# Altium schematic sheet 00_POWER.SchDoc
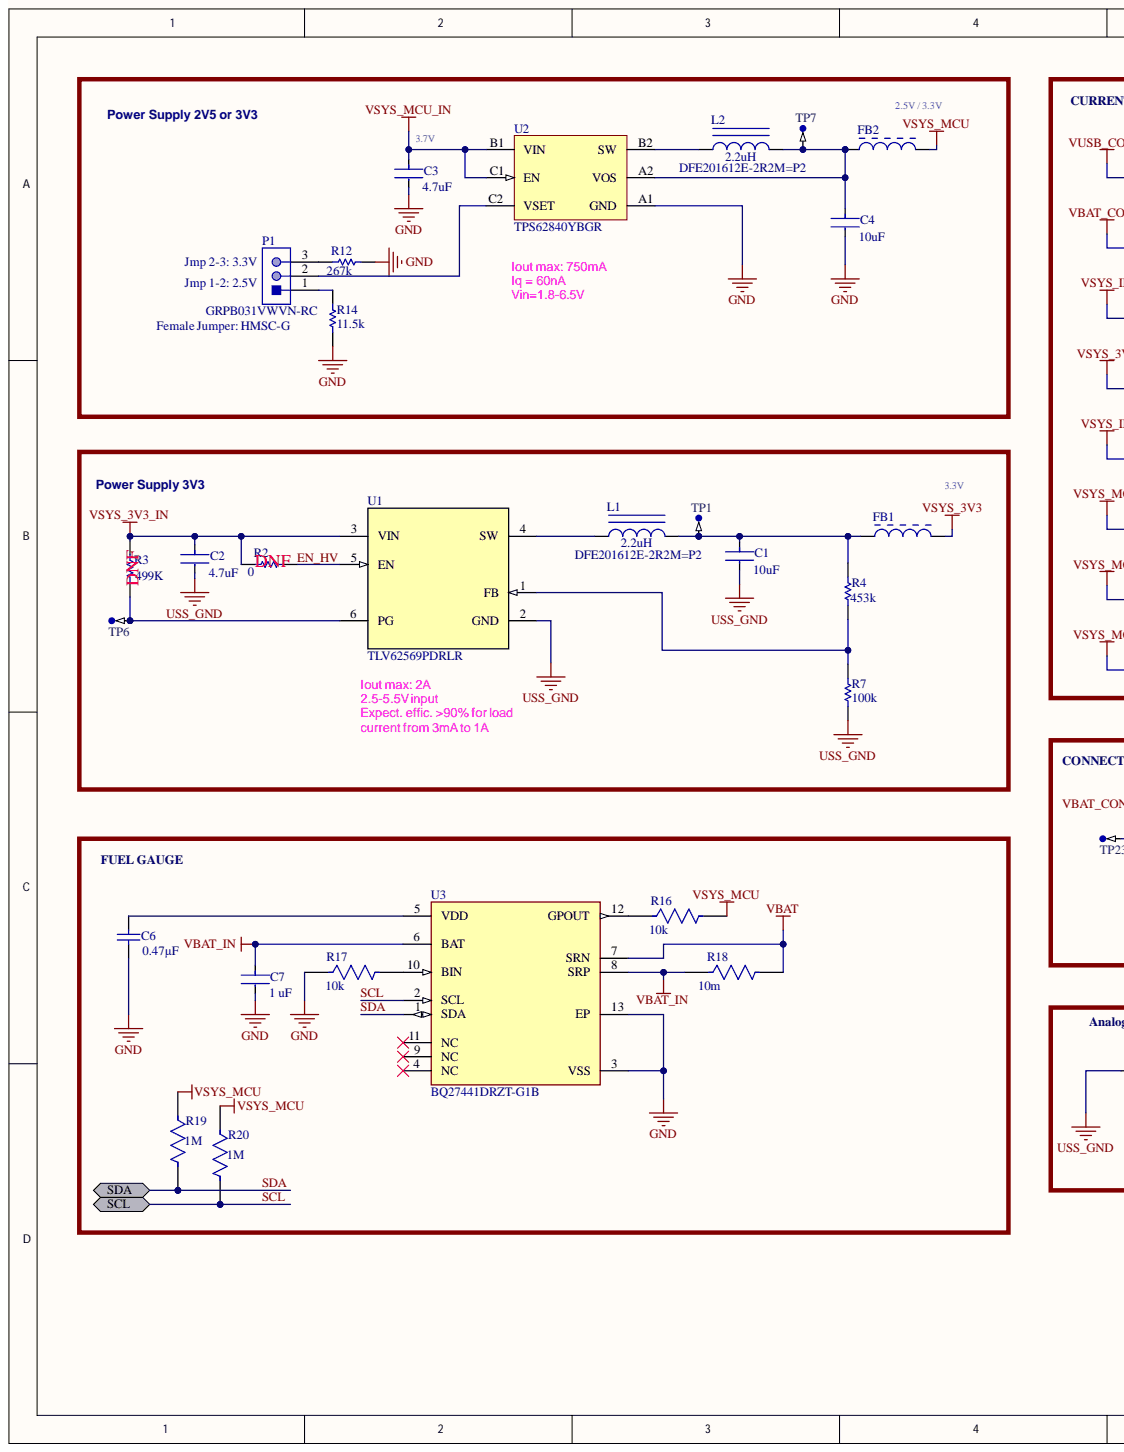
[diagram p0: 00_POWER.SchDoc, left]
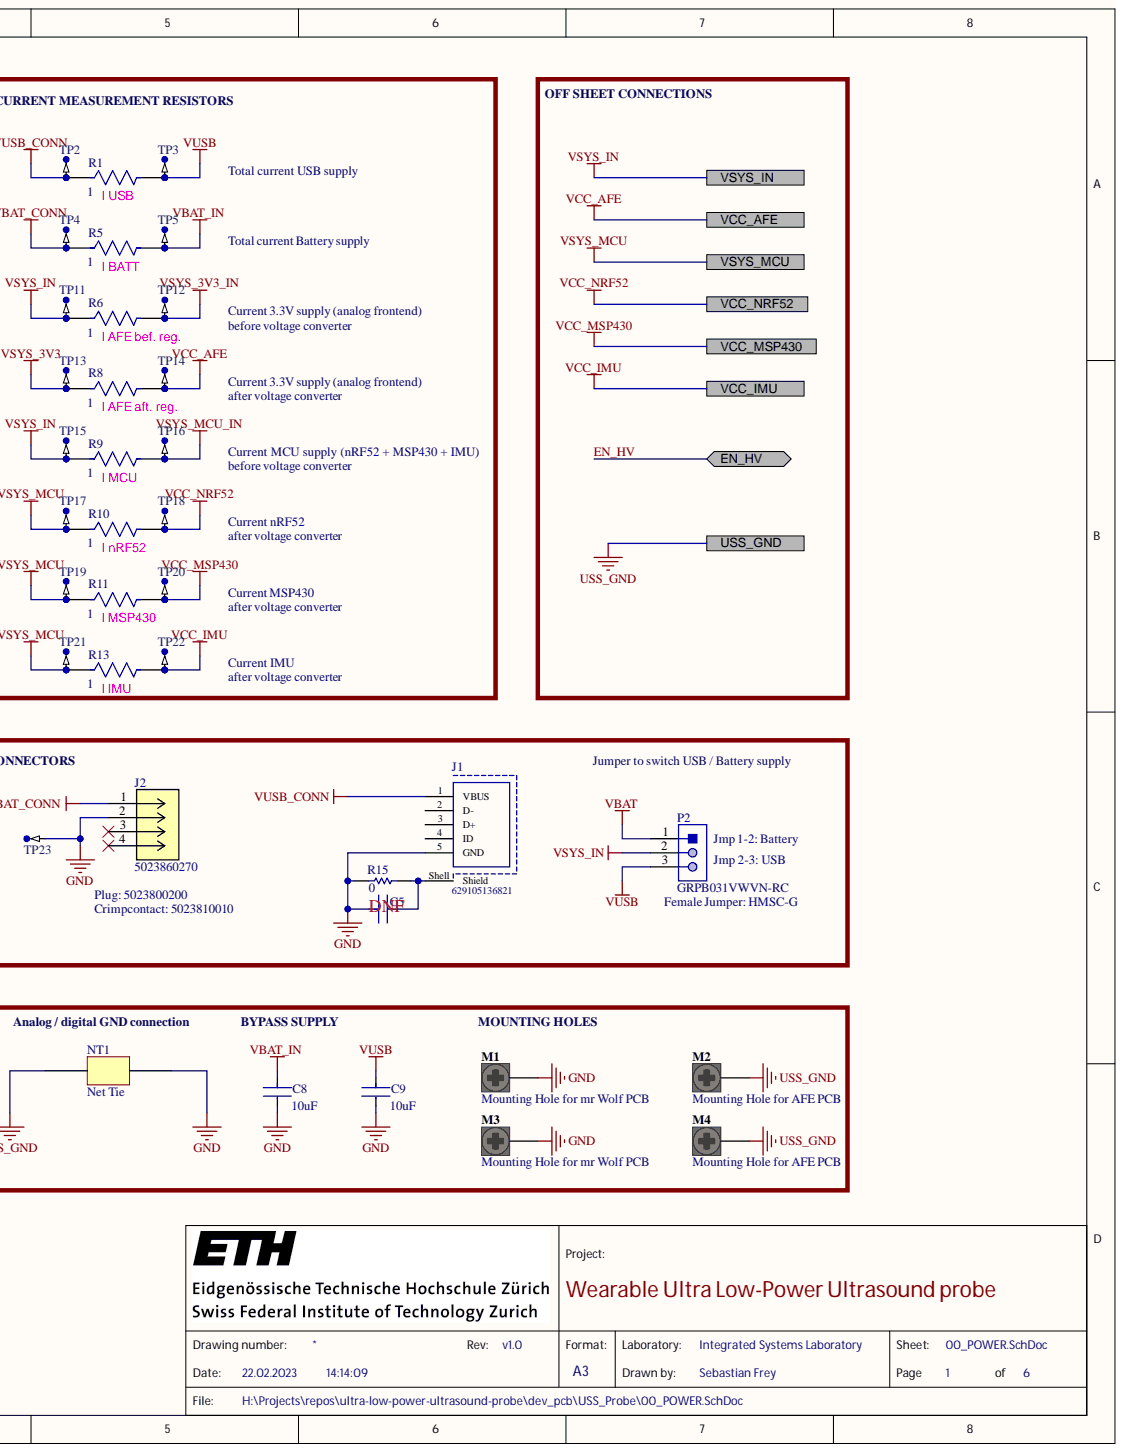
[diagram p1: 00_POWER.SchDoc, right]

## Parts
C1  value 10uF  Capacitance: 10uF  [FP-C1608-080-MFG]  (hidden comment: C1608X5R1A106K080AC)
C2  Capacitance: 4.7uF  [FP-0603-L_1_6_0_15-W_0_8-IPC_A]  (hidden comment: EMK107ABJ475KA-T)
C3  Capacitance: 4.7uF  [FP-0603-L_1_6_0_15-W_0_8-IPC_A]  (hidden comment: EMK107ABJ475KA-T)
C4  value 10uF  Capacitance: 10uF  [FP-C1608-080-MFG]  (hidden comment: C1608X5R1A106K080AC)
C5  CC0402KRX7R7BB104  [FP-CC0402-MFG]  (value not drawn)
C6  Capacitance: 0.47µF  [CAPC1050X55X10LL05]  (hidden comment: C1005X5R1E474K050BB)
C7  value 1 uF  Capacitance: 1 uF  [FP-CC0402-MFG]  (hidden comment: CC0402KRX5R5BB105)
C8  value 10uF  Capacitance: 10uF  [FP-C1608-080-MFG]  (hidden comment: C1608X5R1A106K080AC)
C9  value 10uF  Capacitance: 10uF  [FP-C1608-080-MFG]  (hidden comment: C1608X5R1A106K080AC)
FB1  BLM03AX800SN1D  [MURA-BLM03-CHIP-2_V]  (value not drawn)
FB2  BLM03AX800SN1D  [MURA-BLM03-CHIP-2_V]  (value not drawn)
J1  629105136821  [629105136821]
J2  5023860270  [CON_5023860270_MOL]
L1  DFE201612E-2R2M=P2  value 2.2uH  [INDC2016X10L]
L2  DFE201612E-2R2M=P2  value 2.2uH  [INDC2016X10L]
M1  Mounting Hole for mr Wolf PCB  ClassName: Mounting Hole  [Hole M2]  (no BOM)
M2  Mounting Hole for AFE PCB  ClassName: Mounting Hole  [Hole M2]  (no BOM)
M3  Mounting Hole for mr Wolf PCB  ClassName: Mounting Hole  [Hole M2]  (no BOM)
M4  Mounting Hole for AFE PCB  ClassName: Mounting Hole  [Hole M2]  (no BOM)
NT1  Net Tie  [Net_Tie_Top_Bottom]
P1  GRPB031VWVN-RC  [SLIN-GRPB031VWVN-RC_V]
P2  GRPB031VWVN-RC  [SLIN-GRPB031VWVN-RC_V]
R1  CSR0402FK1R00  [FP-CSR0402-MFG]  (value not drawn)
R2  RC0402JR-070RL  [RESC1005X40X25NL05T10]  (value not drawn)
R3  value 499K  [RESC0402(1005)_N]  (hidden comment: not mounted)
R4  Resistance: 453k  [RESC1005X40X25NL05T10]  (hidden comment: RC0402FR-07453KL)
R5  CSR0402FK1R00  [FP-CSR0402-MFG]  (value not drawn)
R6  CSR0402FK1R00  [FP-CSR0402-MFG]  (value not drawn)
R7  Resistance (Ohms): 100k  [RESC1005X40X25LL10T15]  (hidden comment: RMCF0402FT100K)
R8  CSR0402FK1R00  [FP-CSR0402-MFG]  (value not drawn)
R9  CSR0402FK1R00  [FP-CSR0402-MFG]  (value not drawn)
R10  CSR0402FK1R00  [FP-CSR0402-MFG]  (value not drawn)
R11  CSR0402FK1R00  [FP-CSR0402-MFG]  (value not drawn)
R12  Resistance: 267k  [RESC1005X40X25LL05T05]  (hidden comment: CRCW0402267KFKED)
R13  CSR0402FK1R00  [FP-CSR0402-MFG]  (value not drawn)
R14  Resistance: 11.5k  [RESC1005X40X25ML05T10]  (hidden comment: RC0402FR-0711K5L)
R15  RC0402JR-070RL  [RESC1005X40X25NL05T10]  (value not drawn)
R16  value 10k  [FP-TNPW0402-e3-MFG]  (hidden comment: TNPW040210K0BEED)
R17  value 10k  [FP-TNPW0402-e3-MFG]  (hidden comment: TNPW040210K0BEED)
R18  Resistance: 10m  [FP-CSRF0402-high-IPC_A]  (hidden comment: CSRF0402FT10L0)
R19  Resistance: 1M  [FP-CR0402-MFG]  (hidden comment: CR0402-JW-105GLF)
R20  Resistance: 1M  [FP-CR0402-MFG]  (hidden comment: CR0402-JW-105GLF)
TP1  TP-SM-C-100  [TP-SM-C-100]  (value not drawn)  (no BOM)
TP2  TP-SM-C-100  [TP-SM-C-100]  (value not drawn)  (no BOM)
TP3  TP-SM-C-100  [TP-SM-C-100]  (value not drawn)  (no BOM)
TP4  TP-SM-C-100  [TP-SM-C-100]  (value not drawn)  (no BOM)
TP5  TP-SM-C-100  [TP-SM-C-100]  (value not drawn)  (no BOM)
TP6  TP-SM-C-100  [TP-SM-C-100]  (value not drawn)  (no BOM)
TP7  TP-SM-C-100  [TP-SM-C-100]  (value not drawn)  (no BOM)
TP11  TP-SM-C-100  [TP-SM-C-100]  (value not drawn)  (no BOM)
TP12  TP-SM-C-100  [TP-SM-C-100]  (value not drawn)  (no BOM)
TP13  TP-SM-C-100  [TP-SM-C-100]  (value not drawn)  (no BOM)
TP14  TP-SM-C-100  [TP-SM-C-100]  (value not drawn)  (no BOM)
TP15  TP-SM-C-100  [TP-SM-C-100]  (value not drawn)  (no BOM)
TP16  TP-SM-C-100  [TP-SM-C-100]  (value not drawn)  (no BOM)
TP17  TP-SM-C-100  [TP-SM-C-100]  (value not drawn)  (no BOM)
TP18  TP-SM-C-100  [TP-SM-C-100]  (value not drawn)  (no BOM)
TP19  TP-SM-C-100  [TP-SM-C-100]  (value not drawn)  (no BOM)
TP20  TP-SM-C-100  [TP-SM-C-100]  (value not drawn)  (no BOM)
TP21  TP-SM-C-100  [TP-SM-C-100]  (value not drawn)  (no BOM)
TP22  TP-SM-C-100  [TP-SM-C-100]  (value not drawn)  (no BOM)
TP23  TP-SM-C-100  [TP-SM-C-100]  (value not drawn)  (no BOM)
U1  TLV62569PDRLR  [TLV62569PDRLR]
U2  TPS62840YBGR  [BGA6C40P2X3_97X147X50]
U3  BQ27441DRZT-G1B  [DRZ0012A_MFG]

## Ports
EN_HV, SCL, SDA, USS_GND, VCC_AFE, VCC_IMU, VCC_MSP430, VCC_NRF52, VSYS_IN, VSYS_MCU

## Nets
GND: C3.2 C4.2 C5.1 C6.1 C7.2 C8.2 C9.2 J1.5(GND) J2.2 M1.1 M3.1 NT1.2 R12.1 R14.1 R15.2 R17.1 TP23.1 U2.A1(GND) U3.3(VSS) U3.13(EP)
VBAT: P2.1 R18.2 U3.7(SRN)
VBAT_CONN: J2.1 R5.1 TP4.1
VBAT_IN: C7.1 C8.1 R5.2 R18.1 TP5.1 U3.6(BAT) U3.8(SRP)
VSYS_3V3: FB1.2 R8.1 TP13.1
VSYS_3V3_IN: C2.1 R2.1 R3.2 R6.2 TP12.1 U1.3(VIN)
VSYS_MCU_IN: C3.1 R9.2 TP16.1 U2.B1(VIN) U2.C1(EN)
VUSB: C9.1 P2.3 R1.2 TP3.1
VUSB_CONN: J1.1(VBUS) R1.1 TP2.1
EN_HV: R2.2 U1.5(EN) ; port EN_HV
SCL: R20.2 U3.2(SCL) ; port SCL
SDA: R19.2 U3.1(SDA) ; port SDA
USS_GND: C1.2 C2.2 M2.1 M4.1 NT1.1 R7.1 U1.2(GND) ; port USS_GND
VCC_AFE: R8.2 TP14.1 ; port VCC_AFE
VCC_IMU: R13.2 TP22.1 ; port VCC_IMU
VCC_MSP430: R11.2 TP20.1 ; port VCC_MSP430
VCC_NRF52: R10.2 TP18.1 ; port VCC_NRF52
VSYS_IN: P2.2 R6.1 R9.1 TP11.1 TP15.1 ; port VSYS_IN
VSYS_MCU: FB2.2 R10.1 R11.1 R13.1 R16.2 R19.1 R20.1 TP17.1 TP19.1 TP21.1 ; port VSYS_MCU
NetC1_1: C1.1 FB1.1 L1.2 R4.2 TP1.1
NetC4_1: C4.1 FB2.1 L2.2 TP7.1 U2.A2(VOS)
NetC5_2: C5.2 J1.Shell(Shield) R15.1
NetC6_2: C6.2 U3.5(VDD)
NetL1_1: L1.1 U1.4(SW)
NetL2_1: L2.1 U2.B2(SW)
NetP1_1: P1.1 R14.2
NetP1_2: P1.2 U2.C2(VSET)
NetP1_3: P1.3 R12.2
NetR3_1: R3.1 TP6.1 U1.6(PG)
NetR4_1: R4.1 R7.2 U1.1(FB)
NetR16_1: R16.1 U3.12(GPOUT)
NetR17_2: R17.2 U3.10(BIN)

Not connected: J1 2(D-) 3(D+) 4(ID); J2 3 4; U3 4(NC) 9(NC) 11(NC)

## Library data of the parts
C1 C4 C8 C9 = CMP-2000-04979-2: CAP CER 10UF 10V X5R 0603; Manufacturer: TDK; Material: Ceramic; Temperature Coefficient: 15%; Tolerance: 10%; Automotive: No; Category: Cap; Component Kind: Standard; ComponentLink2Description: Datasheet; ComponentLink2URL: https://product.tdk.com/info/en/documents/chara_sheet/C1608X5R1A106K080AC.pdf; Device Class L1: Passive Components; Device Class L2: Capacitors; Device Class L3: Ceramic Capacitors; Digikey Description: CAP CER 10UF 10V X5R 0603; Footprint Url: https://product.tdk.com/info/en/catalog/spec/mlccspec_commercial_general_midvoltage_en.pdf; Height: 0.9mm; Ipc Land Pattern Name: CAPC16080X80; Lead Free: Yes; Library Name: Altium Content Vault; Manufacturer Part Number: C1608X5R1A106K080AC; Mouser Description: CAP CER 10UF 10V X5R 0603; Octopart: https://octopart.com/c1608x5r1a106k080ac-tdk-26013468; Package: C1608-080; RoHS: TRUE; Temperature: -55°C to +85°C; Temperature Characteristic: X5R; Temperature Range High: +85°C; Temperature Range Low: -55°C; Voltage: 10V; Voltage Rating: 10V
C2 C3 = CMP-2000-06225-3: None; Dielectric: X5R; Tolerance: 10%; Voltage Rating: 16V; Case Code (Imperial): 0603; Case Code (Metric): 1608; Case/Package: 0603; Component Kind: Standard; Construction: Flat; Height: 0.8mm; Length: 1.6mm; Library Name: Altium Content Vault; Max Operating Temperature: 85°C; Min Operating Temperature: -55°C; Mount: Surface Mount; Number of Pins: 2; Package Quantity: 1; Packaging: Tape and Reel; REACH SVHC: No SVHC; Resistor Type: Ceramic; RoHS Compliant: Yes; Series: M; Simulation: EMK107ABJ475_A-T; Termination: SMD/SMT; Thickness: 0.035inch; Voltage Rating (DC): 16V; Width: 0.8mm
C5 = CMP-03422-000048-1: CAP CER 0.1UF 16V X7R 0402; Manufacturer: YAGEO; Automotive Grade: NA; Capacitance: 100 nF; Material: Ceramic; Temperature Coefficient: 30ppm/°C; Tolerance: 0.1; Value: 100 nF; Automotive: No; Category: Cap; Component Kind: Standard; ComponentLink2Description: Datasheet; ComponentLink2URL: http://www.yageo.com/documents/recent/UPY-GP_NP0_16V-to-50V_16.pdf; Device Class L1: Passive Components; Device Class L2: Capacitors; Device Class L3: Ceramic Capacitors; Digikey Description: CAP CER 0.1UF 16V X7R 0402; Footprint Url: http://www.yageo.com/exep/pages/download/literatures/UPY-C_GEN_24.pdf; Height: 0.55mm; Ipc Land Pattern Name: CAPC10050X50; Lead Free: Yes; Library Name: Altium Content Vault; Manufacturer Part Number: CC0402KRX7R7BB104; Mouser Description: 0402 0.1 uF 16 V ±10 % Tolerance X7R SMT Multilayer Ceramic Capacitor; Octopart: https://octopart.com/cc0402krx7r7bb104-yageo-42244624; Package: CC0402; RoHS: TRUE; Temperature: -55°C to +125°C; Temperature Characteristic: X7R; Temperature Range High: +125°C; Temperature Range Low: -55°C; Voltage Rating: 16 V
C6 = CMP-2000-06003-1: Chip Capacitor, 0.47 uF, +/- 10%, 25 V, -55 to 85 degC, 0402 (1005 Metric), RoHS, Tape and Reel; Manufacturer: TDK; PCN Part Number: MLCCPartNumberChange30/Nov/2012; EDA / CAD Models: DownloadfromAcceleratedDesigns; Kits: 445-9021-KIT-ND  -KITMLCC100PF-10UF04026.3-50V; Online Catalog: CSeries,GeneralPurpose; Other Names: 445-8020-1; Product Training Modules: CSeriesGeneralApplicationsSEAT,CCV,andTVCLDesignToolsGuidetoReplacinganElectrolyticCapacitorwithanMLCC; Temperature Coefficient: X5R; Voltage - Rated: 25V; Applications: GeneralPurpose; Category: Capacitors; Component Kind: Standard; Datasheet URL: https://product.tdk.com/info/en/catalog/datasheets/mlcc_commercial_general_en.pdf; Datasheet Version: 08/2016; Family: CeramicCapacitors; Features: LowESL; Library Name: Altium Content Vault; Manufacturer URL: http://www.tdk.com/; Mounting Technology: SurfaceMount; Mounting Type: SurfaceMount,MLCC; Operating Temperature: -55°C~85°C; Package / Case: 0402(1005Metric); Package Description: 2-Pin Surface Mount Device, Body 1.00 x 0.50 mm; Package Reference: 0402; Packaging: CutTape(CT); RoHS Information: RoHSCertificate-MLCC; Series: C; Size / Dimension: 0.039"Lx0.020"W(1.00mmx0.50mm); Standard Package: 1; Tolerance: ±10%
C7 = CMP-2100-03591-2: CAP CER 1UF 6.3V X5R 0402; Manufacturer: YAGEO; Automotive Grade: NA; Material: Ceramic; Temperature Coefficient: 30ppm/°C; Tolerance: 0.1; Automotive: No; Category: Cap; Component Kind: Standard; ComponentLink2Description: Datasheet; ComponentLink2URL: http://www.yageo.com/documents/recent/UPY-GP_NP0_16V-to-50V_16.pdf; Device Class L1: Passive Components; Device Class L2: Capacitors; Device Class L3: Ceramic Capacitors; Digikey Description: CAP CER 1UF 6.3V X5R 0402; Footprint Url: http://www.yageo.com/exep/pages/download/literatures/UPY-C_GEN_24.pdf; Height: 0.55mm; Ipc Land Pattern Name: CAPC10050X50; Lead Free: Yes; Library Name: Altium Content Vault; Manufacturer Part Number: CC0402KRX5R5BB105; Mouser Description: Multilayer Ceramic Capacitors MLCC - SMD/SMT 1uF 6.3V X5R 10%; Octopart: https://octopart.com/cc0402krx5r5bb105-yageo-42244618; Package: CC0402; RoHS: TRUE; Temperature: -55°C to +125°C; Temperature Characteristic: X5R; Temperature Range High: +125°C; Temperature Range Low: -55°C; Voltage Rating: 6.3 V
FB1 FB2 = CMP-0686-00058-2: Chip Ferrite Bead for Power lines and Signal Lines, 80 Ohm, 500 mA, -55 to 125 degC, 0.6 x 0.3 x 0.33 mm SMD, Tape and Reel; Manufacturer: Murata; Current Rating: 500mA; DC Resistance: 0.18 Ohm Max.; DC Resistance (DCR): 180mR; Impedance: 80R; Impedance (at 100 MHz): 80 Ohm +/- 25%; Material: Steel; Number of Circuits: 1; Rated Current: 500 mA; Test Frequency: 100MHz; Tolerance: 25%; Case Code (Imperial): 0201; Case Code (Metric): 0603; Case/Package: 0201; Category: Inductors; Component Kind: Standard; ComponentLink1Description: Manufacturer URL; ComponentLink1URL: http://www.murata.com/; ComponentLink2Description: Datasheet; ComponentLink2URL: http://www.murata.com/products/catalog/pdf/c31e.pdf; ComponentLink3Description: Package Specification; ComponentLink3URL: http://www.murata.com/products/catalog/pdf/c31e.pdf; ComponentLink4Description: https://datasheet.ciiva.com/1013/l0110s0100blm03ax-1013986.pdf?src-supplier=Digi-Key; ComponentLink4URL: https://datasheet.ciiva.com/1013/l0110s0100blm03ax-1013986.pdf?src-supplier=Digi-Key; ComponentLink5Description: https://datasheet.ciiva.com/41449/001172131-da-01-en-drossel-0201-80r-0-6x0-3mm-15000st-41449422.pdf?src-supplier=Conrad; ComponentLink5URL: https://datasheet.ciiva.com/41449/001172131-da-01-en-drossel-0201-80r-0-6x0-3mm-15000st-41449422.pdf?src-supplier=Conrad; ComponentLink6Description: https://datasheet.ciiva.com/1013/l0110e-1013987.pdf?src-supplier=Digi-Key; ComponentLink6URL: https://datasheet.ciiva.com/1013/l0110e-1013987.pdf?src-supplier=Digi-Key; ComponentLink7Description: https://datasheet.ciiva.com/1013/l0110e-1013988.pdf?src-supplier=Digi-Key; ComponentLink7URL: https://datasheet.ciiva.com/1013/l0110e-1013988.pdf?src-supplier=Digi-Key; ComponentLink8Description: https://datasheet.ciiva.com/46423/1851884-46423882.pdf?src-supplier=Element14; ComponentLink8URL: https://datasheet.ciiva.com/46423/1851884-46423882.pdf?src-supplier=Element14; DatasheetVersion: 08/2013; Height: 0.3mm; Length: 0.6mm; Library Name: Altium Content Vault; Manufacturer Part Number: BLM03AX800SN1D; Max Current Rating: 500mA; Max DC Current: 500mA; Max Operating Temperature: 125°C; Min Operating Temperature: -55°C; Mounting Technology: Surface Mount; Number of Lines: 1; Number of Pins: 2; Operating Temperature Range: -55 to 125 degC; Package Quantity: 1; PackageDescription: 2-Pin Chip, Body 0.6 x 0.3 mm; PackageReference: BLM03-2; Packaging: Tape and Reel; Packing: Tape and Reel; PartNumber: BLM03AX800SN1D; Pricing 1: 1=0.19000, 10=0.15200, 25=0.11840, 50=0.09820, 100=0.08470, 250=0.06776, 500=0.06098, 1000=0.05082, 5000=0.04743 (USD); REACH SVHC: No SVHC; RoHS: Yes; Stock 1: 13310; Supplier 1: Digi-Key; Supplier Part Number 1: 490-5621-1-ND; Termination: SMD/SMT; Width: 0.3mm
J1 = CMP-1502-03253-1: Micro USB 2.0 Type B Receptacle WR-COM, Horizontal, SMT, with Pads and Pegs; Manufacturer: Wurth Elektronik; Contact Resistance: 30mOhm; Rated Current: 1A; Working Voltage: 30VAC; Component Kind: Standard; Connector Type: MicroUSB2.0TypeB; Datasheet URL: https://katalog.we-online.de/em/datasheet/629105136821.pdf; Gender: Receptacle; Library Name: Altium Content Vault; Manufacturer URL: http://www.we-online.de; Mounting Technology: SMT; Operating Temperature: -40to105degC; Orientation: Horizontal; Package Reference: 629105136821; Packaging: TapeandReel; Part Number: 629105136821; Publisher: WurthElektronik
J2 = 5023860270: No Description Available; Mfr_Name: Molex Connector Corporation; Component Kind: Standard; Library Name: Schlib1.SchLib; Manufacturer_Part_Number: 5023860270; RefDes: RefDes; Type: DEV; Copyright: Copyright (C) 2021 Ultra Librarian. All rights reserved.
L1 L2 = CMP-01651-1: 2.2µH Shielded Wirewound Inductor 1.8A 116mOhm Max 0806 (2016 Metric); Manufacturer: Murata Electronics; Current - Saturation: 2.4A; Current Rating (Amps): 1,8A; Inductance: 2,2µH; Inductance Frequency - Test: 1MHz; Material - Core: Metal; Tolerance: ±20%; Component Kind: Standard; DC Resistance (DCR): 116mOhm Max; Features: -; Frequency - Self Resonant: -; Library Name: ETHZ Vault; Manufacturer Part Number: DFE201612E-2R2M=P2; Mounting Type: Surface Mount; Operating Temperature: -40°C ~ 125°C; Package / Case: 0806 (2016 Metric); Packaging: Tape & Reel (TR); Part Status: Active; Q @ Freq: -; Ratings: -; Series: DFE201612E; Shielding: Shielded; Supplier Device Package: 0806; Type: Wirewound
M1-M4 = CMP-00274-1: M2 Hole, 2.4mm drill, 5mm landing pads, 3mm pad in middle layers, no overlay; Library Name: ETHZ Vault
NT1 = Net_Tie: Component Kind: Net Tie; Library Name: Schlib1.SchLib
P1 P2 = CMP-2000-06372-1: Male Header, Pitch 1.27 mm, 1 x 3 Position, Height 4 mm, Tail Length 2.3 mm, -40 to 105 degC, RoHS, Bulk; Manufacturer: Sullins; Catalog Drawings: S9014ESeriesTop; Connector Type: Header,Unshrouded; Dynamic Catalog: GRPB; Mating Products: S9010E-03-ND  -CONNHEADER.050"3POSR/APCB  S9008E-03-ND  -CONNHEADER.050"3POSPCBGOLD  S9005E-03-ND  -CONNHEADER.050"3POSSMDGOLD; Number of Positions: 3; Number of Positions Loaded: All; Other Names: S9014E-03; Pitch: 0.050"(1.27mm); Category: Connectors,Interconnects; Component Kind: Standard; Contact Finish: Gold; Contact Finish Thickness: Flash; Contact Mating Length: 0.118"(3.00mm); Contact Type: MalePin; Datasheet URL: http://www.sullinscorp.com/drawings/71_GRPB___1VWVN-RC,_10957-C.pdf; Datasheet Version: Rev. C, 12/2008; Family: RectangularConnectors-Headers,MalePins; Library Name: Altium Content Vault; Manufacturer URL: http://www.sullinscorp.com/; Mounting Technology: ThroughHole; Mounting Type: ThroughHole; Number of Rows: 1; Package Description: Male Header, Pitch 1.27 mm, 1 x 3 Position, Height 4 mm, Tail Length 2.3 mm; Package Reference: GRPB031VWVN-RC; Packaging: Bulk; Standard Package: 1; Termination: Solder
R1 R5 R6 R8-R11 R13 = CMP-26527-000038-1: RES 1 OHM 1% 1/8W 0402; Max Power Dissipation: 125mW; Resistance: 1; Temperature Coefficient: 200ppm/°C; Case Code (Imperial): 0402; Case Code (Metric): 1005; Case/Package: 0402; Component Kind: Standard; Height: 0.017inch; Length: 0.039inch; Library Name: Altium Content Vault; Max Operating Temperature: 155°C; Min Operating Temperature: -55°C; Mount: Surface Mount; Number of Terminations: 2; Package Quantity: 1000; Packaging: Tape and Reel; Resistor Type: Thick Film; RoHS Compliant: Yes; Series: CSR; Tolerance: 1%; Width: 0.02inch
R2 R15 = CMP-1011-00001-2: Manufacturer: Yageo / Phycomp; Resistance: 0; Component Kind: Standard; Library Name: Altium Content Vault; Part Number: RC0402JR-070RL
R3 = CMP-1011-00815-1: 499K 0.063W 1% 0402 (1005 Metric)  SMD; Case-EIA: 0402; Case-Metric: 1005; Component Kind: Standard; Library Name: Altium Content Vault; Power: 0.063 W; Technology: SMT; Tolerance: 1 %
R4 = CMP-2002-07690-1: Manufacturer: Yageo; Component Kind: Standard; Library Name: Altium Content Vault; Part Number: RC0402FR-07453KL
R7 = CMP-2000-06984-1: Chip Resistor, 100 KOhm, +/- 1%, 63 mW, -55 to 155 degC, 0402 (1005 Metric), RoHS, Tape and Reel; Manufacturer: Stackpole-Electronics-Inc.; Power (Watts): 0.063W,1/16W; Temperature Coefficient: ±100ppm/°C; Category: Resistors; Component Kind: Standard; Composition: ThickFilm; Datasheet URL: https://www.seielect.com/Catalog/SEI-RMCF_RMCP.pdf; Datasheet Version: 01/2017; Family: ChipResistor-SurfaceMount; Features: AutomotiveAEC-Q200; Library Name: Altium Content Vault; Manufacturer URL: https://www.seielect.com/; Mounting Technology: SurfaceMount; Number of Terminations: 2; Operating Temperature: -55°C~155°C; Package / Case: 0402(1005Metric); Package Description: 2-Pin Surface Mount Device, Body 1 x 0.5 mm; Package Reference: 0402; Packaging: CutTape(CT); Series: RMCF; Supplier Device Package: 0402; Tolerance: ±1%
R12 = CMP-2002-08420-1: Manufacturer: Vishay Dale; Component Kind: Standard; Library Name: Altium Content Vault; Part Number: CRCW0402267KFKED
R14 = CMP-2002-07364-1: Manufacturer: Yageo / Phycomp; Component Kind: Standard; Library Name: Altium Content Vault; Part Number: RC0402FR-0711K5L
R16 R17 = CMP-2002-01683-2: RES 10K OHM 0.1% 1/10W 0402; Manufacturer: Vishay; Automotive Grade: Grade 0; Power Rating: 0.1W; Resistance: 10kΩ; Temperature Coefficient: 25ppm/°C; Voltage Rating: 50V; Automotive: Yes; Category: Res; Component Kind: Standard; ComponentLink2Description: Datasheet; ComponentLink2URL: http://www.vishay.com/docs/28758/tnpw_e3.pdf; Composition: Thin Film; Device Class L1: Passive Components; Device Class L2: Resistors; Device Class L3: Chip SMD Resistors; Digikey Description: RES 10K OHM 0.1% 1/10W 0402; Height: 0.4mm; Ipc Land Pattern Name: RESC10050X35; Lead Free: Yes; Library Name: Altium Content Vault; Manufacturer Part Number: TNPW040210K0BEED; Octopart: https://octopart.com/tnpw040210k0beed-vishay-41229038; Package: TNPW0402-e3; RoHS: TRUE; Series: TNPW; Temperature: -55°C to +155°C; Temperature Range High: +155°C; Temperature Range Low: -55°C; Tolerance: 0.1%
R18 = CMP-26527-000102-1: RES 0.01 OHM 1% 1/8W 0402; Max Power Dissipation: 125mW; Temperature Coefficient: 200ppm/°C; Case Code (Imperial): 0402; Case Code (Metric): 1005; Case/Package: 0402; Component Kind: Standard; Features: Moisture Resistant; Height: 0.022inch; Lead Free: Lead Free; Length: 0.039inch; Library Name: Altium Content Vault; Max Operating Temperature: 170°C; Min Operating Temperature: -55°C; Mount: Surface Mount; Number of Terminations: 2; Package Quantity: 1; Packaging: Cut Tape; Resistor Type: Metal Foil; RoHS Compliant: Yes; Series: CSRF; Tolerance: 1%; Width: 0.022inch
R19 R20 = CMP-2002-05525-2: Thick Film Chip Resistors 0402 1MΩ 0.0625W 5% 200ppm/°C; Max Power Dissipation: 63mW; Power Rating: 62.5mW; Voltage Rating: 50V; Case Code (Imperial): 0402; Case Code (Metric): 1005; Case/Package: 0402; Component Kind: Standard; Composition: Thick Film; Contact Plating: Tin; Depth: 0.5mm; Height: 0.016inch; Length: 1mm; Library Name: Altium Content Vault; Max Operating Temperature: 125°C; Military Standard: Not; Min Operating Temperature: -55°C; Mount: Surface Mount; Number of Terminations: 2; Package Quantity: 10000; Packaging: Tape and Reel; Radiation Hardening: No; Temperature Coefficient: 200ppm/°C; Termination: SMD/SMT; Thickness: 356um; Tolerance: 5%; Width: 0.02inch
TP1-TP7 TP11-TP23 = CMP-0484-00002-1: Test Point, Surface Mount, Circular, Pad Diameter 1 mm; Library Name: Altium Content Vault
U1 = TLV62569PDRLR: 2.5V-5.5V input, 2-A high efficiency step-down buck converter in SOT23 and SOT563 package 6-SOT-5X3 -40 to 125; Manufacturer: Texas Instruments; Category: Voltage Regulators - Switching; Component Kind: Standard; ComponentLink1Description: http://www.ti.com/general/docs/suppproductinfo.tsp?distId=10&amp;gotoUrl=http%3A%2F%2Fwww.ti.com%2Flit%2Fgpn%2Ftlv62569&src-supplier=Digi-Key; ComponentLink1URL: http://www.ti.com/general/docs/suppproductinfo.tsp?distId=10&amp;gotoUrl=http%3A%2F%2Fwww.ti.com%2Flit%2Fgpn%2Ftlv62569&src-supplier=Digi-Key; ComponentLink2Description: http://www.ti.com/lit/ds/symlink/tlv62569.pdf?src-supplier=Digi-Key; ComponentLink2URL: http://www.ti.com/lit/ds/symlink/tlv62569.pdf?src-supplier=Digi-Key; Library Name: Schlib1.SchLib; Manufacturer Part Number: TLV62569PDRLR; Max Operating Temperature: 125°C; Min Operating Temperature: -40°C; Pricing 1: 1=0.60000, 10=0.52500, 25=0.49280, 100=0.40230, 250=0.37364, 500=0.31800, 1000=0.26250 (USD); RoHS: Yes; RoHS Compliant: Yes; Supplier 1: Digi-Key; Supplier Part Number 1: 296-50408-1-ND
U2 = CMP-01223-1: Switching Voltage Regulators 60-nA IQ, 1.8-V to 6.5-VIN, high-efficiency 750-mA step-down converter 6-DSBGA -40 to 125; Brand: Texas Instruments; Input Voltage: 1.8 V to 6.5 V; Input Voltage MAX: 6.5 V; Input Voltage MIN: 1.8 V; Number of Outputs: 1 Output; Output Current: 750 mA; Output Voltage: 1.8 V to 3.3 V; Quiescent Current: 60 nA; Switching Frequency: 1.8 MHz; Topology: Buck; Component Kind: Standard; Factory Pack Quantity: 3000; Library Name: ETHZ Vault; Manufacturer: Texas Instruments; Maximum Operating Temperature: + 125 C; Minimum Operating Temperature: - 40 C; Mounting Style: SMD/SMT; Package/Case: DSBGA-6; Product Category: Switching Voltage Regulators; Product Type: Switching Voltage Regulators; Series: TPS62840; Shutdown: Shutdown; Subcategory: PMIC - Power Management ICs; Supply Voltage - Min: 1.8 V; Type: Step-Down Converter
U3 = BQ27441DRZT-G1B: System-side Impedance Track™ battery fuel (gas) gauge 12-SON -40 to 85; Manufacturer: Texas Instruments; Capacity: 8000mAh; Interface: I2C; Max Supply Voltage: 4.5V; Min Supply Voltage: 2.45V; Number of Cells: 1; Operating Supply Current: 93uA; Output Current: 500uA; Output Voltage: 1.8V; Category: Battery Management; Component Kind: Standard; ComponentLink1Description: https://datasheet.ciiva.com/46405/1795843-46405045.pdf?src-supplier=Element14; ComponentLink1URL: https://datasheet.ciiva.com/46405/1795843-46405045.pdf?src-supplier=Element14; Contact Plating: Gold; Lead Free: Lead Free; Library Name: Schlib1.SchLib; Manufacturer Part Number: BQ27441DRZT-G1B; Max Operating Temperature: 85°C; Min Operating Temperature: -40°C; Mount: Surface Mount; Number of Pins: 12; Package Quantity: 250; Packaging: Tape and Reel; Pricing 1: 1=3.05000, 10=2.74000, 50=2.59000, 100=2.25000, 1000=2.12000, 10000=2.12000 (USD); REACH SVHC: No SVHC; RoHS: Yes; RoHS Compliant: Yes; Stock 1: 456; Supplier 1: Mouser; Supplier Part Number 1: 595-BQ27441DRZT-G1B
